# Altium schematic sheet motor_drivers.SchDoc(motor_drivers)
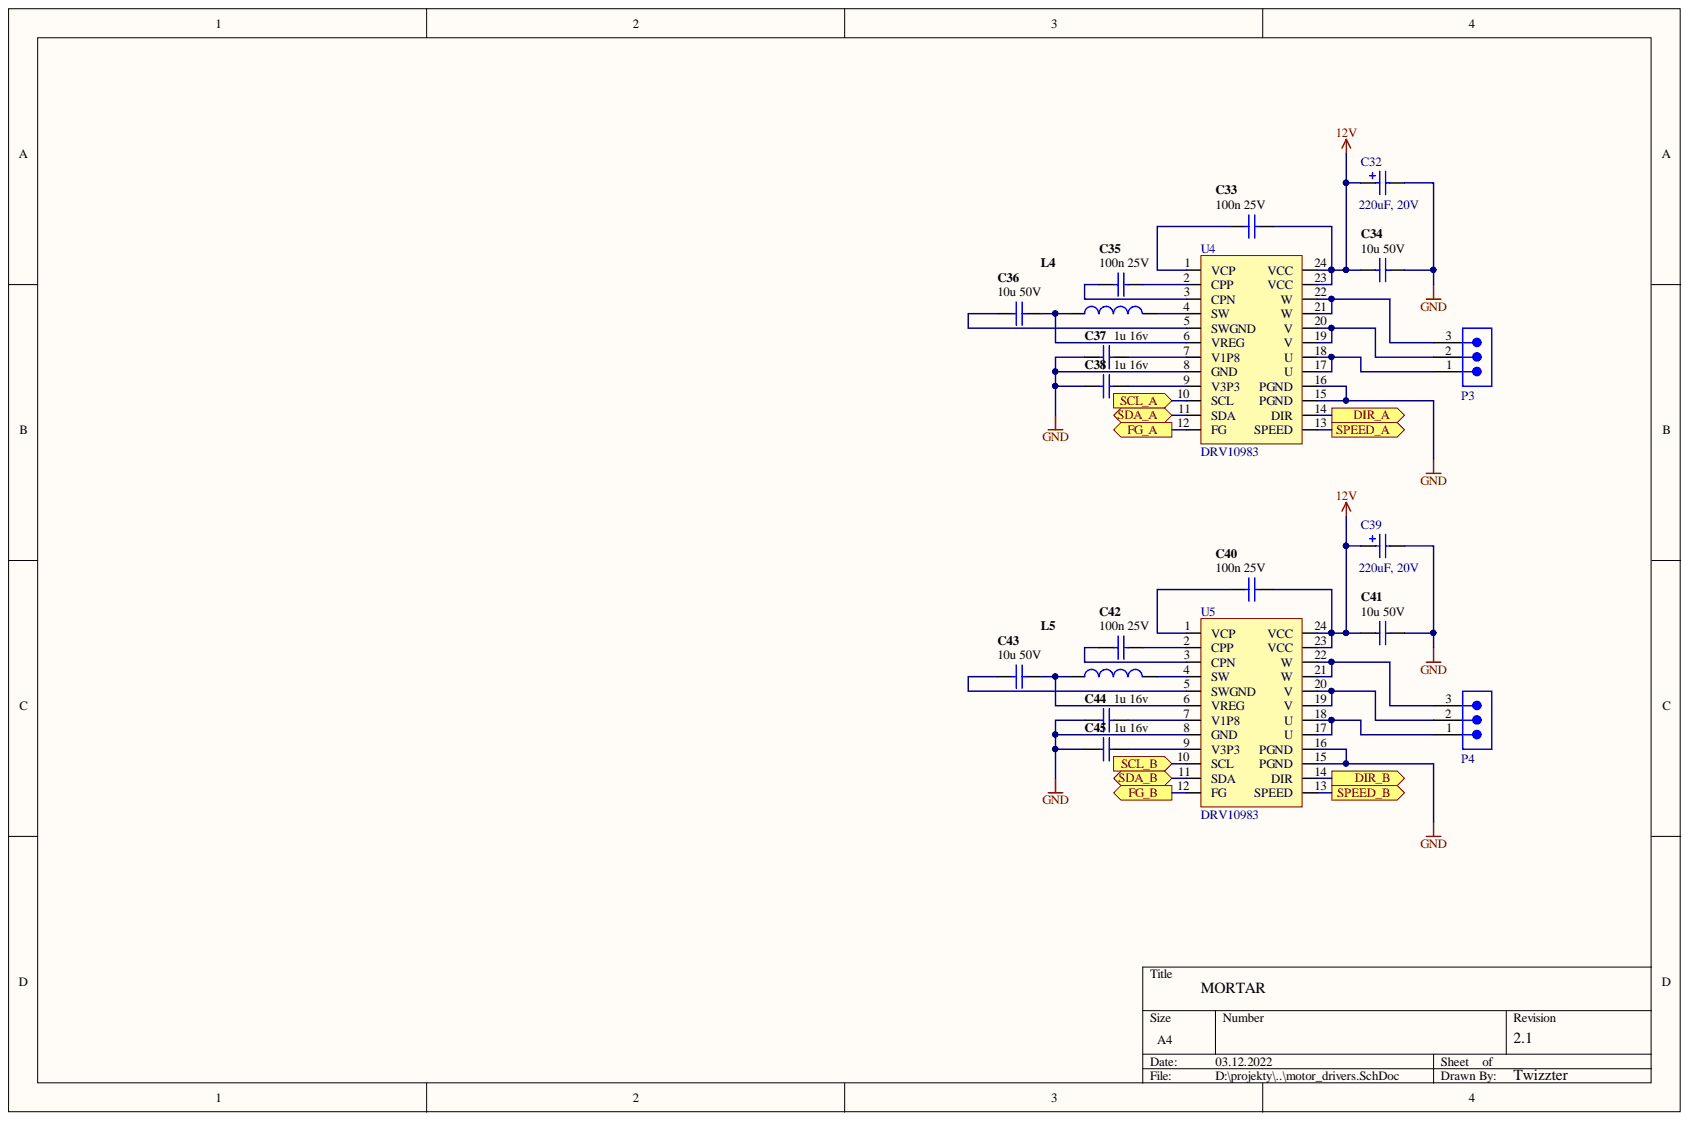
[diagram p0: motor_drivers.SchDoc]

## Parts
C32  220uF, 20V  [WCAP-PSHP_D10H8.7]
C33  value 100n 25V  [C_0603]  (hidden comment: C_100n_25V_0603)
C34  value 10u 50V  [C_1206]  (hidden comment: 10u 50V 1206)
C35  value 100n 25V  [C_0603]  (hidden comment: C_100n_25V_0603)
C36  value 10u 50V  [C_1206]  (hidden comment: 10u 50V 1206)
C37  value 1u 16v  [C_0603]  (hidden comment: C_1u_16V)
C38  value 1u 16v  [C_0603]  (hidden comment: C_1u_16V)
C39  220uF, 20V  [WCAP-PSHP_D10H8.7]
C40  value 100n 25V  [C_0603]  (hidden comment: C_100n_25V_0603)
C41  value 10u 50V  [C_1206]  (hidden comment: 10u 50V 1206)
C42  value 100n 25V  [C_0603]  (hidden comment: C_100n_25V_0603)
C43  value 10u 50V  [C_1206]  (hidden comment: 10u 50V 1206)
C44  value 1u 16v  [C_0603]  (hidden comment: C_1u_16V)
C45  value 1u 16v  [C_0603]  (hidden comment: C_1u_16V)
L4  47uH 1A 2520  [L_2520]  (value not drawn)
L5  47uH 1A 2520  [L_2520]  (value not drawn)
P3  691325310003  [691325310003]  (value not drawn)
P4  691325310003  [691325310003]  (value not drawn)
U4  DRV10983  [TSOP24]
U5  DRV10983  [TSOP24]

## Ports
DIR_A, DIR_B, FG_A, FG_B, SCL_A, SCL_B, SDA_A, SDA_B, SPEED_A, SPEED_B

## Nets
12V: C32.1 C33.1 C34.2 C39.1 C40.1 C41.2 U4.23(VCC) U4.24(VCC) U5.23(VCC) U5.24(VCC)
GND: C32.2 C34.1 C37.2 C38.2 C39.2 C41.1 C44.2 C45.2 U4.8(GND) U4.15(PGND) U4.16(PGND) U5.8(GND) U5.15(PGND) U5.16(PGND)
DIR_A: U4.14(DIR) ; port DIR_A
DIR_B: U5.14(DIR) ; port DIR_B
FG_A: U4.12(FG) ; port FG_A
FG_B: U5.12(FG) ; port FG_B
SCL_A: U4.10(SCL) ; port SCL_A
SCL_B: U5.10(SCL) ; port SCL_B
SDA_A: U4.11(SDA) ; port SDA_A
SDA_B: U5.11(SDA) ; port SDA_B
SPEED_A: U4.13(SPEED) ; port SPEED_A
SPEED_B: U5.13(SPEED) ; port SPEED_B
NetC33_2: C33.2 U4.1(VCP)
NetC35_1: C35.1 U4.2(CPP)
NetC35_2: C35.2 U4.3(CPN)
NetC36_1: C36.1 L4.2 U4.6(VREG)
NetC36_2: C36.2 U4.5(SWGND)
NetC37_1: C37.1 U4.7(V1P8)
NetC38_1: C38.1 U4.9(V3P3)
NetC40_2: C40.2 U5.1(VCP)
NetC42_1: C42.1 U5.2(CPP)
NetC42_2: C42.2 U5.3(CPN)
NetC43_1: C43.1 L5.2 U5.6(VREG)
NetC43_2: C43.2 U5.5(SWGND)
NetC44_1: C44.1 U5.7(V1P8)
NetC45_1: C45.1 U5.9(V3P3)
NetL4_1: L4.1 U4.4(SW)
NetL5_1: L5.1 U5.4(SW)
NetP3_1: P3.1 U4.17(U) U4.18(U)
NetP3_2: P3.2 U4.19(V) U4.20(V)
NetP3_3: P3.3 U4.21(W) U4.22(W)
NetP4_1: P4.1 U5.17(U) U5.18(U)
NetP4_2: P4.2 U5.19(V) U5.20(V)
NetP4_3: P4.3 U5.21(W) U5.22(W)

## Library data of the parts
C32 C39 = 1: WCAP-PSHP Aluminum Polymer Capacitor, V-Chip, SMT, D10xH8.7mm, 220uF, 20V; Manufacturer Part Number: 875115460007; Manufacturer: Wurth Elektronik; Technical Reference: PSEF087221M020DVCTEB000; Ripple Current: 3100mA; Rated Voltage: 20VDC; Leakage Current: 880uA; ESR: 25mOhm; Endurance: 2000h; Dissipation Factor: 10%; Capacitance Tolerance: +/-20%; Published Date: October 2020; Packaging: Tape and Reel; Operating Temperature: -55 to +105degC; Mounting Technology: SMT; Height: 8.7mm; Diameter: 10mm; ComponentLink2URL: https://www.we-online.com/catalog/datasheet/875115460007.pdf; ComponentLink2Description: Datasheet; ComponentLink1URL: http://www.we-online.de; ComponentLink1Description: Manufacturer; Component Kind: Standard; Capacitance: 220uF
C33 C35 C40 C42 = C_100n_25V_0603: 100n 25V 0603 X7R; mfg_pn: 885012206071; Library Name: SCH.SchLib; Component Kind: Standard
C34 C36 C41 C43 = C_1206: 1206; Library Name: SCH.SchLib; Component Kind: Standard
C37 C38 C44 C45 = C_1u_16V: mfg_pn: EMK107B7105KA-T; Library Name: SCH.SchLib; Component Kind: Standard
L4 L5 = L_LQM2HPN1R0MG0L: SMD-Multilayer Inductor; PackageVersion: 2009; PackageReference: SMD-0603; Library Name: SCH.SchLib; Component Kind: Standard
P3 P4 = 691325310003: WR-TBL Series 3253 Horizontal PCB Header with Flanges, Through Hole, pitch 3.81mm, 3p; Manufacturer: Wurth Elektronik; Working Voltage: 300V; Rated Current: 10A; Pin Number: 3; Modularity: No; Pitch: 3.81mm; PCB/Cable/Panel: PCB; Packaging: Box; Orientation: Horizontal; Operating Temperature Min: -40°C; Operating Temperature Max: 105°C; Mount: Through Hole; Match Code: WR-TBL; Manufacturer Part Number: 691325310003; Library Name: SCH.SchLib; ComponentLink2URL: https://www.we-online.com/redexpert/spec/691325310003?ad; ComponentLink2Description: Datasheet; ComponentLink1URL: https://www.we-online.com/redexpert/article/691325310003?ad; ComponentLink1Description: Manufacturer Link; Component Kind: Standard; Category: Terminal Blocks; Application: Pluggable
U4 U5 = DRV10983: 12- to 24-V, Three-Phase, Sensorless BLDC Motor Driver; Library Name: SCH.SchLib; Component Kind: Standard
